# Altium schematic sheet USB and aother interfaces.SchDoc
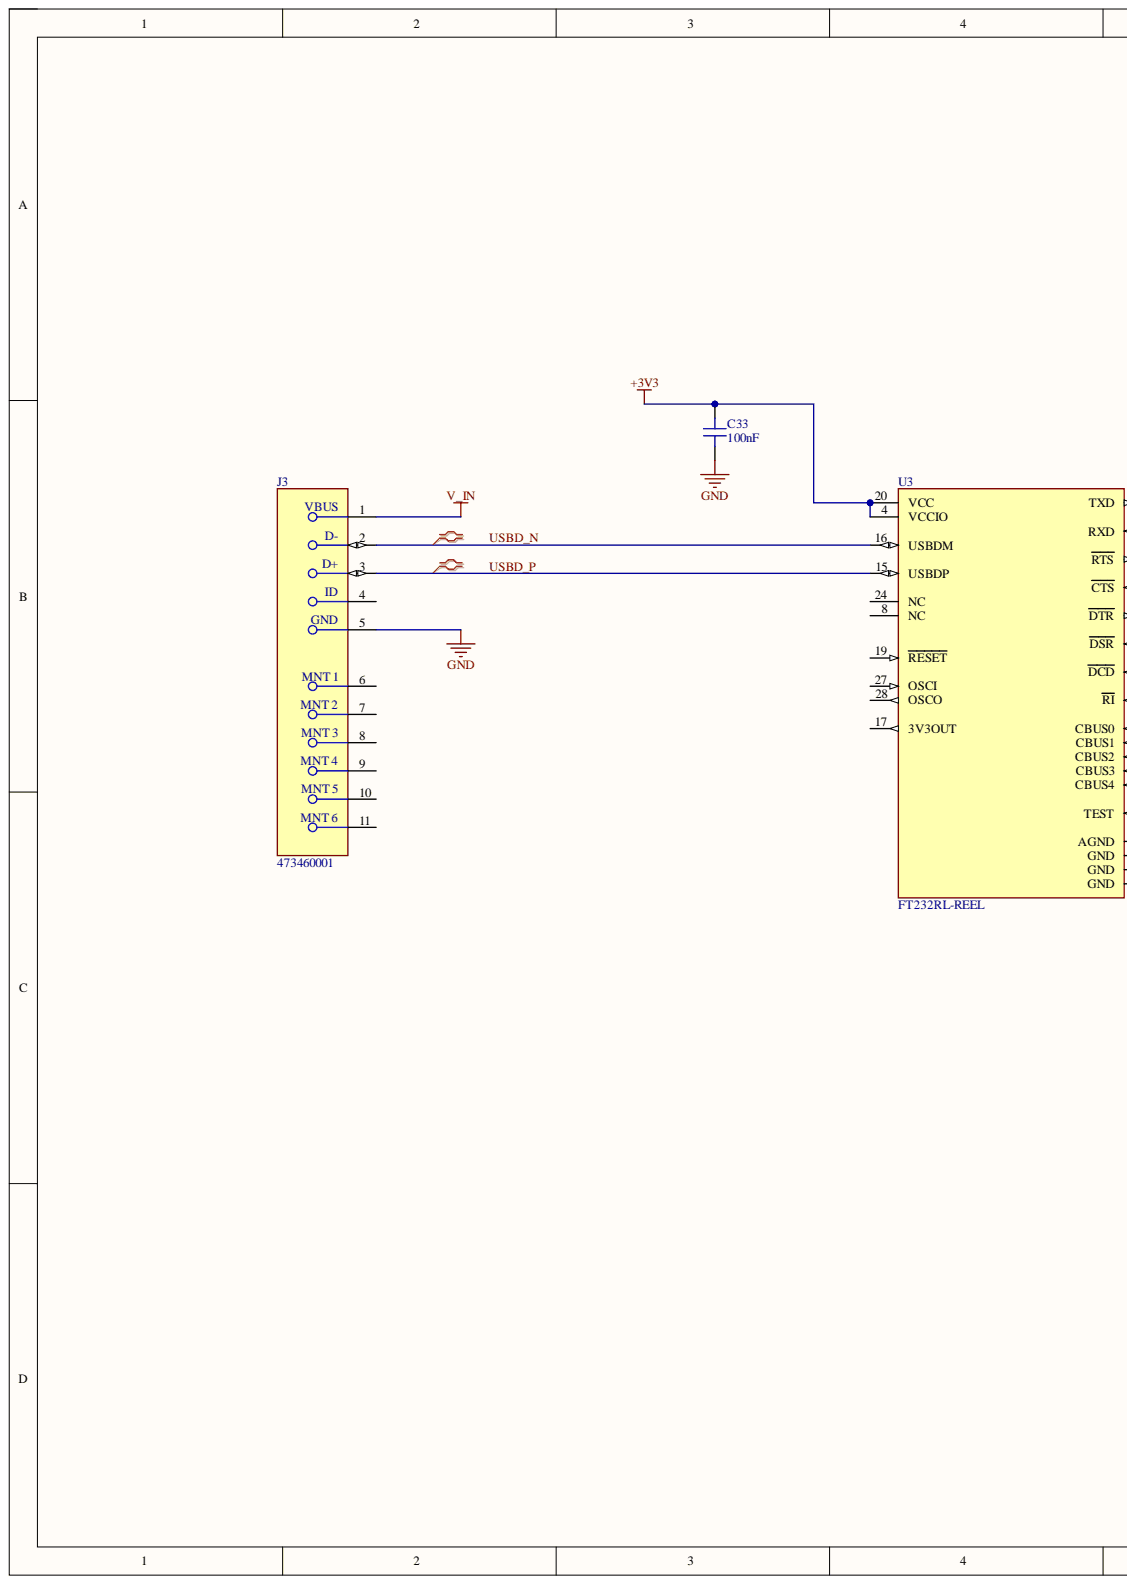
[diagram p0: USB and aother interfaces.SchDoc, left]
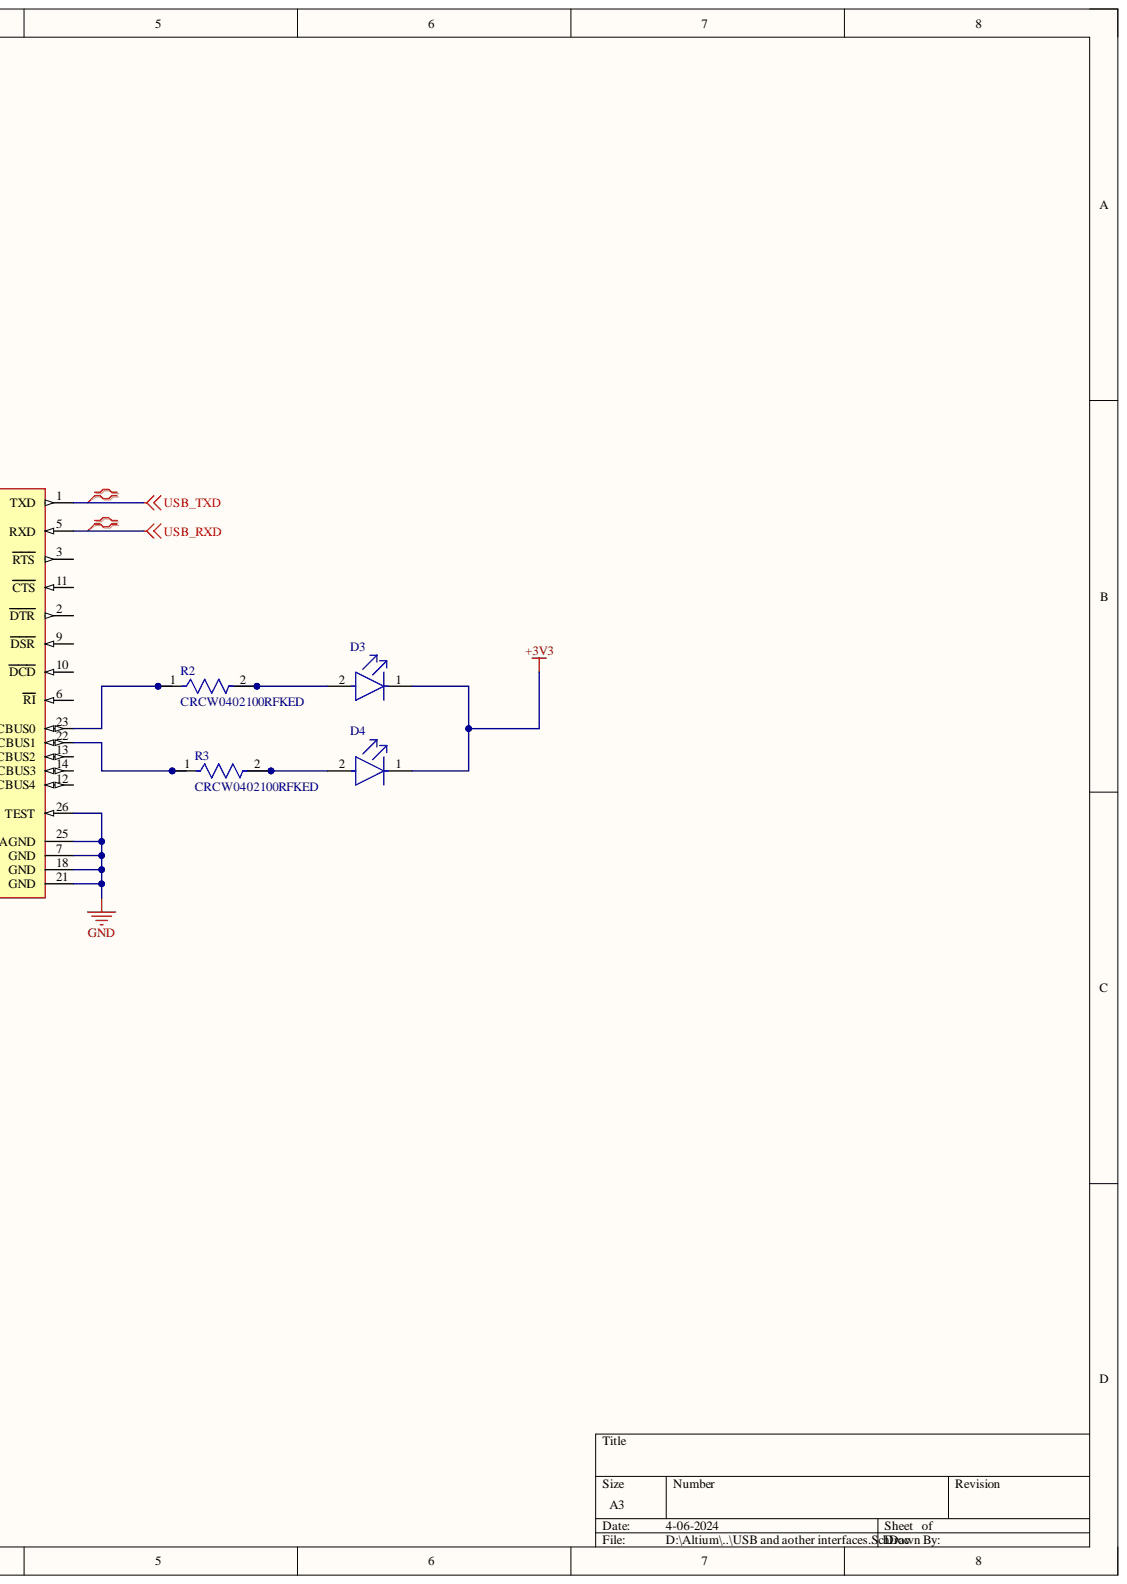
[diagram p1: USB and aother interfaces.SchDoc, right]

## Parts
C33  100nF  [CAP 0402_1005]
D3  APHHS1005CGCK  [FP-APHHS1005CGCK-MFG]  (value not drawn)
D4  APHHS1005CGCK  [FP-APHHS1005CGCK-MFG]  (value not drawn)
J3  473460001  [FP-473460001-MFG]
R2  CRCW0402100RFKED  [FP-CRCW0402-e3-IPC_C]
R3  CRCW0402100RFKED  [FP-CRCW0402-e3-IPC_C]
U3  FT232RL-REEL  [FP-SSOP-28-MFG]

## Nets
+3V3: C33.2 D3.1 D4.1 U3.4(VCCIO) U3.20(VCC)
GND: C33.1 J3.5 U3.7(GND) U3.18(GND) U3.21(GND) U3.25(AGND) U3.26(TEST)
USB_RXD: U3.5(RXD)
USB_TXD: U3.1(TXD)
V_IN: J3.1
USBD_N: J3.2 U3.16(USBDM)
USBD_P: J3.3 U3.15(USBDP)
NetD3_2: D3.2 R2.2
NetD4_2: D4.2 R3.2
NetR2_1: R2.1 U3.23(CBUS0)
NetR3_1: R3.1 U3.22(CBUS1)

Not connected: J3 4 6 7 8 9 10 11; U3 2(DTR) 3(RTS) 6(RI) 8(NC) 9(DSR) 10(DCD) 11(CTS) 12(CBUS4) 13(CBUS2) 14(CBUS3) 17(3V3OUT) 19(RESET) 24(NC) 27(OSCI) 28(OSCO)

## Library data of the parts
C33 = Cap 0402: SMT Capacitor; Component Kind: Standard; Library Name: Capacitor.IntLib; VALUE: 100nF
D3 D4 = CMP-44529-000044-1: LED GREEN CLEAR CHIP SMD; Dominant Wavelength: 570nm; Forward Current: 20mA; Forward Voltage: 2.1V; Luminous Intensity: 50mcd; Number of LEDs: 1; Viewing Angle: 120°; Case Code (Imperial): 0402; Case Code (Metric): 1005; Case/Package: 0402; Color: Green; Component Kind: Standard; Height: 0.6mm; Illumination Color: Green; Lead Free: Lead Free; Length: 1mm; Lens Color: Clear; Lens Style: Clear; Library Name: Altium Content Vault; Max Operating Temperature: 85°C; Min Operating Temperature: -40°C; Mount: Surface Mount; Number of Pins: 2; Package Quantity: 2000; Packaging: Tape and Reel; Test Current: 20mA; Wavelength: 570nm; Width: 0.5mm
J3 = CMP-2000-05246-2: CONN RCPT USB2.0 MICRO B SMD R/A; Manufacturer: Molex; Conn Orientation: Right Angle; Current Rating: 1.8A; Is Female: yes; Number Of Contacts: 5; Pitch: 0.65mm; Shielding: true; Voltage Rating: 30V; Automotive: No; Category: Conn; Component Kind: Standard; ComponentLink2Description: Datasheet; ComponentLink2URL: https://www.molex.com/webdocs/datasheets/pdf/en-us/0473460001_IO_CONNECTORS.pdf; Contact Material: Gold,Nickel; Device Class L1: Connectors; Device Class L2: USB Connectors; Device Class L3: unset; Digikey Description: CONN RCPT USB2.0 MICRO B SMD R/A; Footprint Url: https://www.molex.com/pdm_docs/sd/473460001_sd.pdf; Height: 2.945mm; Lead Free: Yes; Library Name: Altium Content Vault; Manufacturer Part Number: 473460001; Octopart: https://octopart.com/47346-0001-molex-7164928; Package: 0; RoHS: TRUE; Temperature: -30°C to +85°C; Temperature Range High: +85°C; Temperature Range Low: -30°C; Usb Standard: USB 2.0
R2 R3 = CMP-1011-00397-3: RES Thick Film, 100Ω, 1%, 0.063W, 100ppm/°C, 0402; Max Power Dissipation: 63mW; Resistance: 100R; Voltage Rating: 50V; Case Code (Imperial): 0402; Case Code (Metric): 1005; Case/Package: 0402; Component Kind: Standard; Composition: Thick Film; Contact Plating: Tin; Depth: 0.5mm; Features: Automotive AEC-Q200; Height: 0.35mm; Lead Free: Lead Free; Length: 1mm; Library Name: Altium Content Vault; Max Operating Temperature: 155°C; Military Standard: Not; Min Operating Temperature: -55°C; Mount: Surface Mount; Number of Terminations: 2; Package Quantity: 10; Packaging: Tape and Reel; Power Rating: 63mW; Radiation Hardening: No; Temperature Coefficient: 100ppm/°C; Termination: SMD/SMT; Tolerance: 1%; Voltage Rating (DC): 50V; Weight: 2.3E-05oz; Width: 0.02inch; Working Voltage: 50V
U3 = CMP-0078-00029-4: IC USB FS SERIAL UART 28-SSOP; Data Rate: 12Mbps; Max Supply Voltage: 5.25V; Min Supply Voltage: 3.3V; Number of Channels: 1; Operating Supply Current: 15mA; Voltage: 5V; Case/Package: SSOP; Component Kind: Standard; Height: 1.75mm; Interface: UART; Lead Free: Lead Free; Length: 10.2mm; Library Name: Altium Content Vault; Max Operating Temperature: 85°C; Min Operating Temperature: -40°C; Mount: Surface Mount; Number of Pins: 28; Number of Transceivers: 1; Package Quantity: 2000; Packaging: Tape and Reel; Radiation Hardening: No; REACH SVHC: No SVHC; Weight: 0.080001oz; Width: 5.3mm
